# Altium schematic sheet mcu.SchDoc
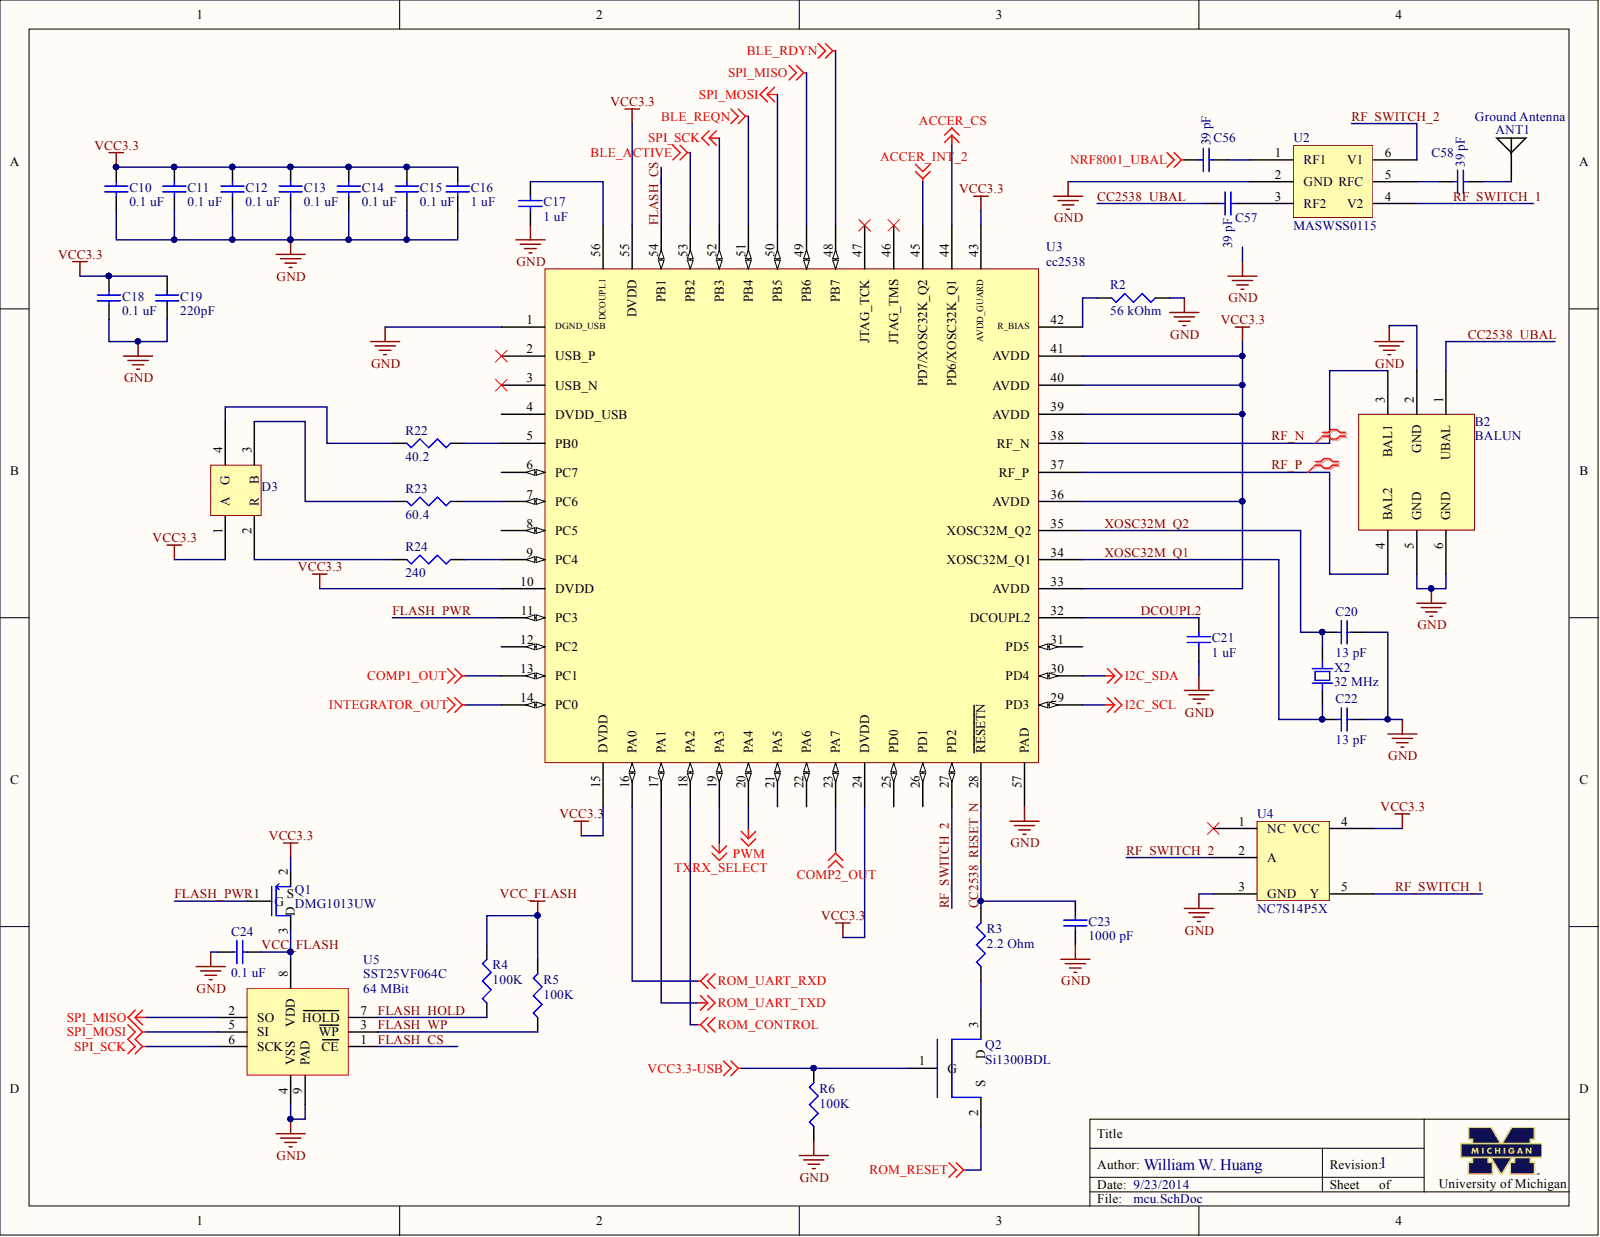
[diagram p0: mcu.SchDoc]

## Parts
ANT1  Ground Antenna  [SMD Ground Antenna]
B2  BALUN  [Chip Balun]
C10  value 0.1 uF  [0402]  (hidden comment: Ceramic capacitor)
C11  value 0.1 uF  [0402]  (hidden comment: Ceramic capacitor)
C12  value 0.1 uF  [0402]  (hidden comment: Ceramic capacitor)
C13  value 0.1 uF  [0402]  (hidden comment: Ceramic capacitor)
C14  value 0.1 uF  [0402]  (hidden comment: Ceramic capacitor)
C15  value 0.1 uF  [0402]  (hidden comment: Ceramic capacitor)
C16  value 1 uF  [0402]  (hidden comment: Ceramic capacitor)
C17  value 1 uF  [0402]  (hidden comment: Ceramic capacitor)
C18  value 0.1 uF  [0402]  (hidden comment: Ceramic capacitor)
C19  value 220pF  [0402]  (hidden comment: 220pF 0402 ceramic cap)
C20  value 13 pF  [0402]  (hidden comment: 13pf 0402 Cap)
C21  value 1 uF  [0402]  (hidden comment: Ceramic capacitor)
C22  value 13 pF  [0402]  (hidden comment: 13pf 0402 Cap)
C23  value 1000 pF  [0402]  (hidden comment: Ceramic capacitor)
C24  value 0.1 uF  [0402]  (hidden comment: Ceramic capacitor)
C56  Ceramic Capacitor  [0402]  (value not drawn)
C57  Ceramic Capacitor  [0402]  (value not drawn)
C58  Ceramic Capacitor  [0402]  (value not drawn)
D3  Tri Color LED  [0404]  (value not drawn)
Q1  DMG1013UW  [SC70-3]
Q2  Si1300BDL  [SC70-3]
R2  value 56 kOhm  [0402]  (hidden comment: 56k 0402 Res)
R3  value 2.2 Ohm  [0402]  (hidden comment: 2.2 Ohm 0402 Res)
R4  value 100K  [0402]  (hidden comment: Chip resistor)
R5  value 100K  [0402]  (hidden comment: Chip resistor)
R6  value 100K  [0402]  (hidden comment: Chip resistor)
R22  value 40.2  [0201]  (hidden comment: Chip Resistor)
R23  value 60.4  [0201]  (hidden comment: Chip resistor)
R24  value 240  [0201]  (hidden comment: Chip resistor)
U2  MASWSS0115  [SC70-6]
U3  cc2538  [QFN50P800X800X100_HS-57N]
U4  NC7S14P5X  [SC70-5]
U5  SST25VF064C  Capacity: 64 MBit  [WSON]
X2  value 32 MHz  [FA-128]  (hidden comment: 32 MHz Crystal)

## Nets
ACCER_CS: U3.44(PD6/XOSC32K_Q1)
ACCER_INT_2: U3.45(PD7/XOSC32K_Q2)
BLE_ACTIVE: U3.53(PB2)
BLE_RDYN: U3.48(PB7)
BLE_REQN: U3.51(PB4)
COMP1_OUT: U3.13(PC1)
COMP2_OUT: U3.23(PA7)
GND: B2.2(GND) B2.5(GND) B2.6(GND) C10.2 C11.2 C12.2 C13.2 C14.2 C15.2 C16.2 C17.2 C18.2 C19.2 C20.2 C21.2 C22.1 C23.2 C24.1 R2.2 R6.2 U2.2(GND) U3.1(DGND_USB) U3.57(PAD) U4.3(GND) U5.4(VSS) U5.9(PAD)
I2C_SCL: U3.29(PD3)
I2C_SDA: U3.30(PD4)
INTEGRATOR_OUT: U3.14(PC0)
NRF8001_UBAL: C56.1
PWM: U3.20(PA4)
ROM_CONTROL: U3.18(PA2)
ROM_RESET: Q2.2
ROM_UART_RXD: U3.16(PA0)
ROM_UART_TXD: U3.17(PA1)
SPI_MISO: U3.49(PB6) U5.2(SO)
SPI_MOSI: U3.50(PB5) U5.5(SI)
SPI_SCK: U3.52(PB3) U5.6(SCK)
TXRX_SELECT: U3.19(PA3)
VCC3.3: C10.1 C11.1 C12.1 C13.1 C14.1 C15.1 C16.1 C18.1 C19.1 D3.1(A) Q1.2 U3.10(DVDD) U3.15(DVDD) U3.24(DVDD) U3.33(AVDD) U3.36(AVDD) U3.39(AVDD) U3.40(AVDD) U3.41(AVDD) U3.43(AVDD_GUARD) U3.55(DVDD) U4.4(VCC)
VCC3.3-USB: Q2.1 R6.1
CC2538_RESET_N: C23.1 R3.1 U3.28(RESETN)
CC2538_UBAL: B2.1(UBAL) C57.2
DCOUPL2: C21.1 U3.32(DCOUPL2)
FLASH_CS: U3.54(PB1) U5.1(CE)
FLASH_HOLD: R4.2 U5.7(HOLD)
FLASH_PWR: Q1.1 U3.11(PC3)
FLASH_WP: R5.2 U5.3(WP)
RF_N: B2.3(BAL1) U3.38(RF_N)
RF_P: B2.4(BAL2) U3.37(RF_P)
RF_SWITCH_1: U2.4(V2) U4.5(Y)
RF_SWITCH_2: U2.6(V1) U3.27(PD2) U4.2(A)
VCC_FLASH: C24.2 Q1.3 R4.1 R5.1 U5.8(VDD)
XOSC32M_Q1: C22.2 U3.34(XOSC32M_Q1) X2.3
XOSC32M_Q2: C20.1 U3.35(XOSC32M_Q2) X2.1
NetANT1_1: ANT1.1 C58.2
NetC17_1: C17.1 U3.56(DCOUPL1)
NetC56_2: C56.2 U2.1(RF1)
NetC57_1: C57.1 U2.3(RF2)
NetC58_1: C58.1 U2.5(RFC)
NetD3_2: D3.2(R) R24.1
NetD3_3: D3.3(B) R23.1
NetD3_4: D3.4(G) R22.1
NetQ2_3: Q2.3 R3.2
NetR2_1: R2.1 U3.42(R_BIAS)
NetR22_2: R22.2 U3.5(PB0)
NetR23_2: R23.2 U3.7(PC6)
NetR24_2: R24.2 U3.9(PC4)

Not connected: U3 2(USB_P) 3(USB_N) 4(DVDD_USB) 6(PC7) 8(PC5) 12(PC2) 21(PA5) 22(PA6) 25(PD0) 26(PD1) 31(PD5) 46(JTAG_TMS) 47(JTAG_TCK); U4 1(NC)

## Library data of the parts
ANT1 = Molex Antenna: Molex 2.4 GHz SMD On-Ground Antenna; Component Kind: Standard; Supplier 1: Digikey; Supplier Part Number 1: WM4893CT-ND; Library Name: opo_general.SchLib
B2 = cc2538_Balun: Johanson CC253X Balun; Component Kind: Standard; Supplier 1: Digikey; Supplier Part Number 1: 712-1536-1-ND; Library Name: opo_general.SchLib
C10-C15 C18 C24 = C_0.1u_0402_ceramic: Ceramic capacitor, 0.1u, 0402 package; Manufacture: TDK; tolerance: 10%; voltage rated: 10 V; Component Kind: Standard; Library Name: rlc.SchLib; package: 0402; Supplier 1: Digi-Key; Supplier Part Number 1: 445-1265-1-ND
C16 C17 C21 = C_1u_0402_ceramic: Ceramic capacitor, 1 uF, 0402 package; Manufacture: TDK; tolerance: 10%; voltage rated: 6.3 V; Component Kind: Standard; Library Name: rlc.SchLib; package: 0402; Supplier 1: Digi-Key; Supplier Part Number 1: 445-5000-1-ND
C19 = C_220pf_0402_ceramic: 220pF 5% 50 V C0G NP0 0402 ceramic cap; Mfr: Murata; Mfr Part Number: GRM1555C1H221JA01D; Component Kind: Standard; Library Name: rlc.SchLib; Supplier 1: Digikey; Supplier Part Number 1: 490-1293-1-ND
C20 C22 = C_13p_0402: 13pf, 50V, 2%, c0G, NP0, 0402, ceramic; Mfr: Murata; Mfr Part Number: GRM1555C1H130GA01D; Component Kind: Standard; Library Name: rlc.SchLib; Supplier 1: Digikey; Supplier Part Number 1: 490-8184-1-ND
C23 = C_1000p_0402_ceramic: Ceramic capacitor, 1000 pF, 0402 package; Manufacture: TDK; tolerance: 10%; voltage rated: 50 V; Component Kind: Standard; Library Name: rlc.SchLib; package: 0402; Supplier 1: Digi-Key; Supplier Part Number 1: 445-6846-1-ND
C56-C58 = C_39pf_0402_ceramic: Ceramic capacitor, 0402, 39 pF, 5%, C0G, NP0, RF suitable; Component Kind: Standard; Library Name: rlc.SchLib; Supplier 1: Digikey; Supplier Part Number 1: 720-1288-1-ND
D3 = D_LED_RGB_0404: LED, RGB, 0404; Component Kind: Standard; Library Name: rlc.SchLib; Supplier 1: Digikey; Supplier Part Number 1: SMLP34RGB2W3CT-ND
Q1 = DMG1013UW: P-CHANNEL ENHANCEMENT MODE MOSFET; Component Kind: Standard; Supplier 1: Digi-Key; Supplier Part Number 1: DMG1013UW-7DICT-ND; Library Name: opo_general.SchLib
Q2 = Si1300BDL: MOSFET N-CH D-S 20V SC-70-3; Component Kind: Standard; Supplier 1: Digi-Key; Supplier Part Number 1: SI1300BDL-T1-GE3DKR-ND; Library Name: opo_general.SchLib
R2 = R_56K_0402: 56 kOhm, 1%, .0603 W, 0402; Mfr: Rohm; Mfr Part Number: MCR01MRTF5602; Component Kind: Standard; Library Name: rlc.SchLib; Supplier 1: Digikey; Supplier Part Number 1: RHM56.0KCDCT-ND
R3 = R_2.2_0402: 2.2 Ohm, 1%, .063W, 0402; Mfr: Vishay Dale; Mfr Part Number: CRCW04022R20FKED; Component Kind: Standard; Library Name: rlc.SchLib; Supplier 1: Digikey; Supplier Part Number 1: 541-2.20LLCT-ND
R4-R6 = R_100K_0402: Chip resistor, 100K, 0402 package; power: 1/16 W; tolerance: 1%; Component Kind: Standard; Library Name: rlc.SchLib; package: 0402; Supplier 1: Digi-Key; Supplier Part Number 1: 541-100KLCT-ND
R22 = R_40.2_0201: 40.2 Ohm 0201 1% Chip Resistor; Component Kind: Standard; Library Name: rlc.SchLib; Supplier 1: Digikey; Supplier Part Number 1: 1276-3586-1-ND
R23 = R_60.4_0201: 60.4 Ohm, .05W, 1% 0201 Chip Resistor; Component Kind: Standard; Library Name: rlc.SchLib; Supplier 1: DIgikey; Supplier Part Number 1: P60.4ABCT-ND
R24 = R_240_0201: 240 Ohm, 1%, .05W, 0201 Chip Resistor; Component Kind: Standard; Library Name: rlc.SchLib; Supplier 1: Digikey; Supplier Part Number 1: P240AGCT-ND
U2 = MASWSS0115: Rf Switch; Mfr: M/A-Com; Mfr Part Number: MASWSS0115TR-3000; Component Kind: Standard; Supplier 1: Digikey; Supplier Part Number 1: 1465-1373-1-ND; Library Name: opo_general.SchLib
U3 = cc2538: cc2538 MCU/802.15.4 radio; Component Kind: Standard; Library Name: opo_general.SchLib
U4 = NC7S14P5X: Single Inverter; Mfr: Fairchild; Component Kind: Standard; Mfr Part Number: NC7S14P5X; Supplier 1: Digikey; Supplier Part Number 1: NC7S14P5XDKR-ND; Library Name: opo_general.SchLib
U5 = SST25VF064C: 64 Mbit SPI Serial Dual I/O Flash; Component Kind: Standard; Supplier 1: Digi-Key; Supplier Part Number 1: SST25VF064C-80-4I-Q2AE-CT-ND; Library Name: opo_general.SchLib
X2 = X_32M_FA-128: 32MHz, 10ppm, 10pF, 60 Ohm; Mfr: Epson; Mfr Part Number: FA-128 32.0000MF20X-K3; Component Kind: Standard; Supplier 1: Digikey; Supplier Part Number 1: SER3800CT-ND; Library Name: opo_general.SchLib
